# Altium schematic sheet Power_Delivery.SchDoc(Power_Delivery)
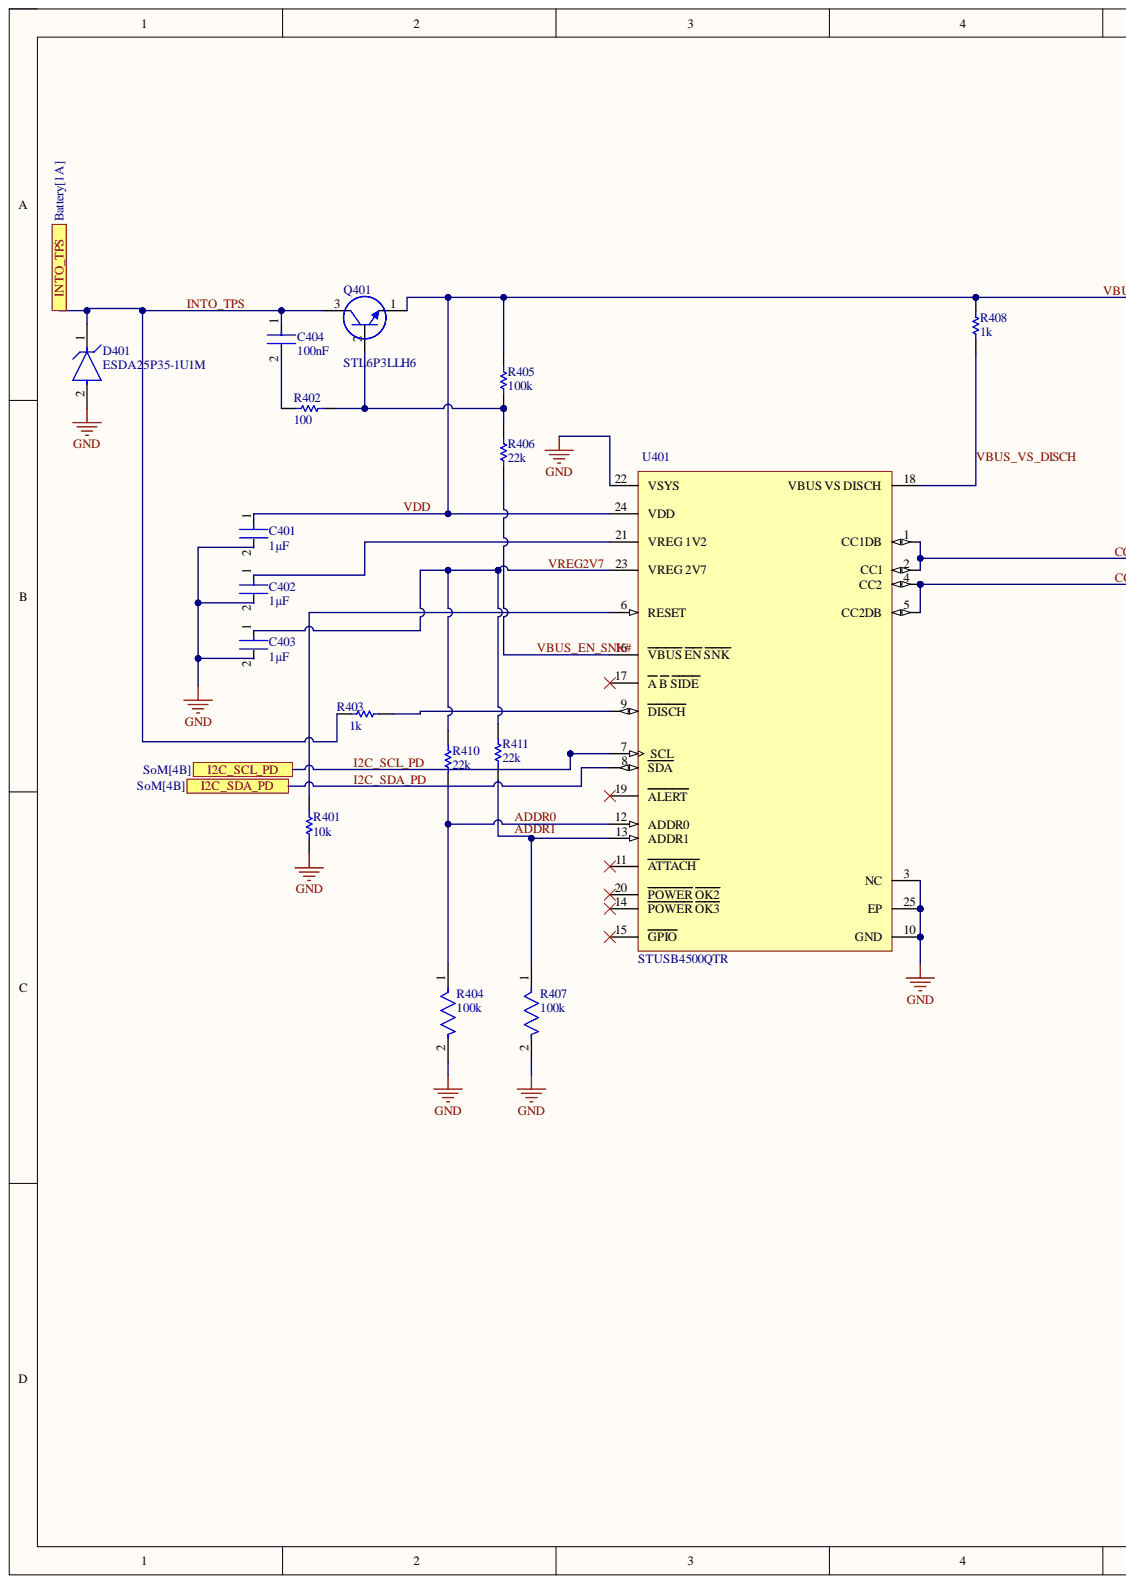
[diagram p0: Power_Delivery.SchDoc, left]
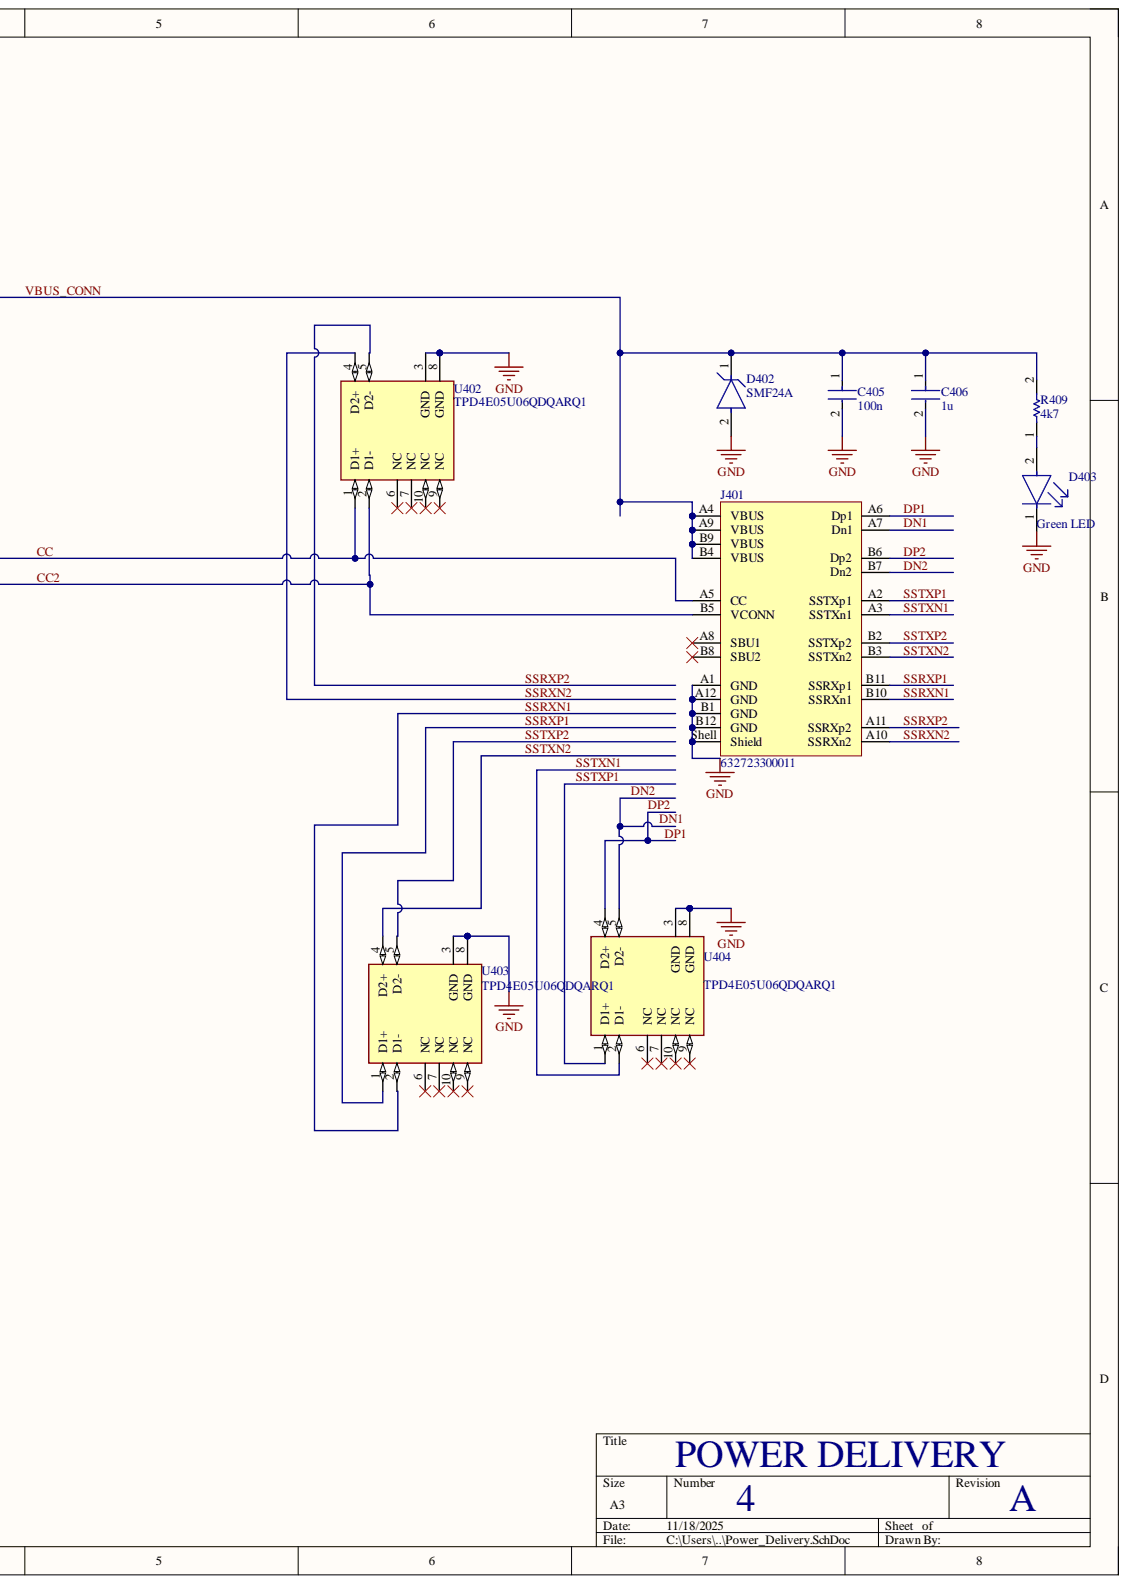
[diagram p1: Power_Delivery.SchDoc, right]

## Parts
C401  1µF  [FP-GRM15-0_1-MFG]
C402  1µF  [FP-GRM15-0_1-MFG]
C403  1µF  [FP-GRM15-0_1-MFG]
C404  100nF  [FP-GRM155-0_05-IPC_B]
C405  100n  [FP-GRM155-0_05-IPC_B]
C406  1u  [FP-GRM155-0_1-IPC_C]
D401  ESDA25P35-1U1M  [FP-QFN_1610-MFG]
D402  SMF24A  [FP-SMF24A-MFG]
D403  Green LED  Color: Green  [FP-LTST-C190GKT-MFG]
J401  632723300011  [632723300011]
Q401  STL6P3LLH6  [TRANS_STL6P3LLH6]
R401  10k  [RESC1005X40X25LL05T10]
R402  100  [RESC1005X40X25ML05T10]
R403  1k  [RESC3216X60X45ML15T20]
R404  100k  [FP-RC0402-0_4-IPC_A]
R405  100k  [RESC1005X40X25NL05T10]
R406  22k  [RESC1005X40X25NL05T05]
R407  100k  [FP-RC0402-0_4-IPC_A]
R408  1k  [RESC3216X60X45ML15T20]
R409  4k7  [RESC1005X40X25NL10T10]
R410  22k  [RESC1005X40X25NL05T10]
R411  22k  [RESC1005X40X25NL05T10]
U401  STUSB4500QTR  [FP-QFN-24-EP_4x4-MFG]
U402  TPD4E05U06QDQARQ1  [FP-DQA0010A-MFG]
U403  TPD4E05U06QDQARQ1  [FP-DQA0010A-MFG]
U404  TPD4E05U06QDQARQ1  [FP-DQA0010A-MFG]

## Ports
I2C_SCL_PD, I2C_SDA_PD, INTO_TPS

## Nets
GND: C401.2 C402.2 C403.2 C405.2 C406.2 D401.2 D402.2 D403.1 J401.A1(GND) J401.A12(GND) J401.B1(GND) J401.B12(GND) J401.Shell(Shield) R401.1 R404.2 R407.2 U401.3(NC) U401.10(GND) U401.22(VSYS) U401.25(EP) U402.3(GND) U402.8(GND) U403.3(GND) U403.8(GND) U404.3(GND) U404.8(GND)
ADDR0: R404.1 R410.1 U401.12(ADDR0)
ADDR1: R407.1 R411.1 U401.13(ADDR1)
CC: J401.A5(CC) U401.1(CC1DB) U401.2(CC1) U402.1(D1+)
CC2: J401.B5(VCONN) U401.4(CC2) U401.5(CC2DB) U402.2(D1-)
DN1: J401.A7(Dn1) J401.B7(Dn2) U404.5(D2-)
DP1: J401.A6(Dp1) J401.B6(Dp2) U404.4(D2+)
I2C_SCL_PD: U401.7(SCL) ; port I2C_SCL_PD
I2C_SDA_PD: U401.8(SDA) ; port I2C_SDA_PD
INTO_TPS: C404.1 D401.1 Q401.3 R403.2 ; port INTO_TPS
SSRXN1: J401.B10(SSRXn1) U403.2(D1-)
SSRXN2: J401.A10(SSRXn2) U402.4(D2+)
SSRXP1: J401.B11(SSRXp1) U403.1(D1+)
SSRXP2: J401.A11(SSRXp2) U402.5(D2-)
SSTXN1: J401.A3(SSTXn1) U404.2(D1-)
SSTXN2: J401.B3(SSTXn2) U403.4(D2+)
SSTXP1: J401.A2(SSTXp1) U404.1(D1+)
SSTXP2: J401.B2(SSTXp2) U403.5(D2-)
VBUS_CONN: C401.1 C405.1 C406.1 D402.1 J401.A4(VBUS) J401.A9(VBUS) J401.B4(VBUS) J401.B9(VBUS) Q401.1 R405.2 R408.2 R409.2 U401.24(VDD)
VBUS_EN_SNK#: R406.1 U401.16(VBUS_EN_SNK)
VBUS_VS_DISCH: R408.1 U401.18(VBUS_VS_DISCH)
VREG2V7: C403.1 R410.2 R411.2 U401.23(VREG_2V7)
NetC402_1: C402.1 U401.21(VREG_1V2)
NetC404_2: C404.2 R402.1
NetD403_2: D403.2 R409.1
NetQ401_2: Q401.2 R402.2 R405.1 R406.2
NetR401_2: R401.2 U401.6(RESET)
NetR403_1: R403.1 U401.9(DISCH)

Not connected: J401 A8(SBU1) B8(SBU2); U401 11(ATTACH) 14(POWER_OK3) 15(GPIO) 17(A_B_SIDE) 19(ALERT) 20(POWER_OK2); U402 6(NC) 7(NC) 9(NC) 10(NC); U403 6(NC) 7(NC) 9(NC) 10(NC); U404 6(NC) 7(NC) 9(NC) 10(NC)

## Library data of the parts
C401-C403 = CMP-2008-04556-2: CAP CER 1UF 10V X5R 0402; Manufacturer: Murata; Manufacturer Part Number: GRM155R61A105KE15D; Material: Ceramic; Temperature Coefficient: 15%; Tolerance: 10%; Automotive: No; Capacitance: 1uF; Category: Cap; Component Kind: Standard; ComponentLink2Description: Datasheet; ComponentLink2URL: https://search.murata.co.jp/Ceramy/image/img/A01X/G101/ENG/GRM155R61A105KE15-01A.pdf; Device Class L1: Passive Components; Device Class L2: Capacitors; Device Class L3: Ceramic Capacitors; Digikey Description: CAP CER 1UF 10V X5R 0402; Height: 0.55mm; Ipc Land Pattern Name: CAPC10050X50; Lead Free: Yes; Library Name: Altium Content Vault; Mouser Description: 0402 1 uF 10 V ±10% Tolerance X5R Surface Mount Multilayer Ceramic Capacitor; Octopart: https://octopart.com/grm155r61a105ke15d-murata-197442; Package: GRM15-0.1; RoHS: TRUE; Temperature: -55°C to +85°C; Temperature Characteristic: X5R; Temperature Range High: +85°C; Temperature Range Low: -55°C; Value: 1uF; Voltage Rating: 10V
C404 = CMP-2008-04639-2: Chip Multilayer Ceramic Capacitors for General Purpose, 0402, 0.10uF, X7R, 15%, 10%, 50V; Dissipation Factor: 0.025%; Capacitance: 100nF; Case Code (Imperial): 0402; Case Code (Metric): 1005; Case/Package: 0402; Component Kind: Standard; Depth: 0.5mm; Dielectric: X7R; Dielectric Material: Ceramic; Height: 0.5mm; Lead Free: Lead Free; Length: 1mm; Library Name: Altium Content Vault; Material: Ceramic; Max Operating Temperature: 125°C; Min Operating Temperature: -55°C; Mount: Surface Mount; Package Quantity: 10000; Packaging: Tape and Reel; REACH SVHC: No SVHC; Resistor Type: Ceramic; Termination: SMD/SMT; Thickness: 0.022inch; Tolerance: 10%; Voltage: 50V; Voltage Rating: 50V; Voltage Rating (DC): 50V; Weight: 0.000212oz; Width: 0.5mm
C405 = CMP-06035-003982-1: Chip Multilayer Ceramic Capacitors for General Purpose, 0402, 0.10uF, X5R, 15%, 10%, 50V; Capacitance: 100nF; Dissipation Factor: 0.025%; Case Code (Imperial): 0402; Case Code (Metric): 1005; Case/Package: 0402; Component Kind: Standard; Depth: 0.5mm; Dielectric: X5R; Dielectric Material: Ceramic; Height: 0.5mm; Lead Free: Lead Free; Length: 1mm; Library Name: Altium Content Vault; Max Operating Temperature: 85°C; Min Operating Temperature: -55°C; Mount: Surface Mount; Package Quantity: 10000; Packaging: Tape and Reel; REACH SVHC: No SVHC; Resistor Type: Ceramic; Termination: SMD/SMT; Thickness: 0.022inch; Tolerance: 10%; Voltage: 50V; Voltage Rating: 50V; Voltage Rating (DC): 50V; Weight: 0.000212oz; Width: 0.02inch
C406 = CMP-06035-026280-1: Chip Multilayer Ceramic Capacitors for General Purpose, 0402, 1.0uF, X5R, 15%, 10%, 25V; Capacitance: 1uF; Component Kind: Standard; Dielectric: X5R; Length: 1mm; Library Name: Altium Content Vault; Thickness: 500um; Tolerance: 10%; Voltage Rating (DC): 25V; Width: 500um
D401 = CMP-12225-000030-1: TVS DIODE 22V 41V 1610; Manufacturer: STMicroelectronics; Breakdown Voltage: 23.3V; Clamping Voltage: 39V; Direction: Unidirectional; Max Junction Temp: +150°C; Number Of Channels: 1; Peak Pulse Current: 35A; Peak Pulse Power: 1400W; Standoff Height: 0.02mm; Automotive: No; Category: Diode; Component Kind: Standard; ComponentLink2Description: Datasheet; ComponentLink2URL: https://www.st.com/resource/en/datasheet/esda25p35-1u1m.pdf; Device Class L1: Circuit Protection; Device Class L2: TVS Diodes; Device Class L3: unset; Digikey Description: TVS DIODE 22V 41V 1610; Height: 0.6mm; Lead Free: Yes; Library Name: Altium Content Vault; Manufacturer Part Number: ESDA25P35-1U1M; Mouser Description: ESDA Series 1400 W 25 V Uni-Directional Surface Mount TVS Diode - QFN-1610; Octopart: https://octopart.com/esda25p35-1u1m-stmicroelectronics-75302699; Package: QFN_1610; Reverse Standoff Voltage: 22V; RoHS: TRUE; Temperature: -55°C to +150°C; Temperature Range High: +150°C; Temperature Range Low: -55°C
D402 = CMP-08607-000108-1: TVS DIODE 24V 38.9V SOD123F; Breakdown Voltage: 29.5V; Leakage Current: 1uA; Max Surge Current: 5.1A; Min Breakdown Voltage: 26.7V; Number of Channels: 1; Peak Pulse Power: 200W; Polarity: Unidirectional; Power Line Protection: No; Test Current: 1mA; Clamping Voltage: 38.9V; Component Kind: Standard; Composition: Zener; Lead Free: Lead Free; Library Name: Altium Content Vault; Max Breakdown Voltage: 29.5V; Max Operating Temperature: 150°C; Min Operating Temperature: -55°C; Mount: Surface Mount; Number of Elements: 1; Number of Pins: 2; Number of Unidirectional Channels: 1; Packaging: Tape and Reel; Peak Pulse Current: 5.1A; Reverse Breakdown Voltage: 26.7V; Reverse Standoff Voltage: 24V; Termination: SMD/SMT
D403 = CMP-22017-000005-2: LED GREEN CLEAR CHIP SMD; Dominant Wavelength: 569nm; Forward Current: 30mA; Forward Voltage: 2.1V; Lens Color: Colorless; Luminous Intensity: 6mcd; Number of Elements: 1; Power Dissipation: 100mW; Reverse Voltage: 5V; Test Current: 10mA; Viewing Angle: 130°; Voltage: 2V; Wavelength: 565nm; Case Code (Imperial): 0603; Case Code (Metric): 1608; Case/Package: 0603; Component Kind: Standard; Depth: 0.8mm; Height: 0.8mm; Illumination Color: Green; Lead Free: Lead Free; Length: 1.6mm; Lens Style: Clear; Lens Transparency: Clear; Library Name: Altium Content Vault; Max Operating Temperature: 85°C; Min Operating Temperature: -55°C; Mount: Surface Mount; Number of LEDs: 1; Number of Pins: 2; Package Quantity: 1; Packaging: Tape and Reel; Power Rating: 100mW; Radiation Hardening: No; Width: 0.8mm
J401 = CMP-002-00072-1: Conn USB 3.1 Type C RCP 24 POS 0.5mm/0.4mm Solder RA SMD/Thru-Hole 24 Terminal 1 Port T/R; Gender: Receptacle; Voltage Rating: 20V; Component Kind: Standard; Connector Type: USB; Max Operating Temperature: 105°C; Min Operating Temperature: -40°C; Mounting Technology: Surface Mount, Through Hole; Pins: 24; Library Name: Evan
Q401 = CMP-013-00034-1: P-channel 30 V, 0.024 Ohm typ., 6 A STripFET H6 Power MOSFET in a PowerFLAT 3.3 x 3.3 package; Component Kind: Standard; Max Operating Temperature: 150°C; Mounting Technology: Surface Mount; Pins: 8; Library Name: Evan
R401 = CMP-2002-07353-1: Manufacturer: Yageo / Phycomp; Part Number: RC0402FR-0710KL; Component Kind: Standard; Library Name: Altium Content Vault
R402 = CMP-2002-07344-1: Manufacturer: Yageo / Phycomp; Part Number: RC0402FR-07100RL; Component Kind: Standard; Library Name: Altium Content Vault
R403 R408 = CMP-2100-03616-1: Chip Resistor, 1 KOhm, +/- 1%, 0.25 W, -55 to 155 degC, 1206 (3216 Metric), RoHS, Tape and Reel; Manufacturer: Vishay Semiconductor; Max Power Dissipation: 250mW; Resistance: 1KOhm; Temperature Coefficient: 100ppm/degreesC; Voltage Rating: 200V; Case Code (Imperial): 1206; Case Code (Metric): 3216; Case/Package: 1206; Component Kind: Standard; Composition: ThickFilm; Contact Plating: Tin; Datasheet URL: http://www.farnell.com/datasheets/1720486.pdf; Datasheet Version: 41061.0; Depth: 1.6mm; Height: 0.55mm; Lead Free: LeadFree; Length: 3.2mm; Library Name: Altium Content Vault; Manufacturer URL: http://www.vishay.com/; Max Operating Temperature: 155degC; Military Standard: Not; Min Operating Temperature: -55degC; Mount: SurfaceMount; Number of Pins: 2; Number of Terminations: 2; Package Description: 2-Pin Surface Mount Device, Body 3.15 x 1.6 mm; Package Quantity: 10; Package Reference: 1206.0; Packaging: TapeandReel; Power Rating: 250mW; Radiation Hardening: No; REACH SVHC: Unknown; RoHSCompliant: true; Termination: SMD/SMT; Tolerance: 1%; Voltage Rating (DC): 200V; Weight: 0.000353oz; Width: 0.063inch
R404 R407 = CMP-2002-07913-2: RES 100K OHM 5% 1/16W 0402; Max Power Dissipation: 63mW; Resistance: 100kR; Temperature Coefficient: 100ppm/°C; Voltage Rating: 50V; Case Code (Imperial): 0402; Case Code (Metric): 1005; Case/Package: 0402; Component Kind: Standard; Construction: Rectangular; Depth: 0.5mm; Features: Moisture Resistant; Height: 0.016inch; Lead Free: Lead Free; Length: 1mm; Library Name: Altium Content Vault; Max Operating Temperature: 155°C; Military Standard: Not; Min Operating Temperature: -55°C; Number of Terminations: 2; Package Quantity: 1; Packaging: Tape and Reel; Power Rating: 63mW; Resistance Tolerance: 5%; Resistor Type: Thick Film; RoHS Compliant: Yes; Series: RC; Size Code: 0402; Termination: SMD/SMT; Tolerance: 5%; Width: 0.02inch
R405 = CMP-2002-07343-1: Manufacturer: Yageo / Phycomp; Part Number: RC0402FR-07100KL; Component Kind: Standard; Library Name: Altium Content Vault
R406 = CMP-1011-00659-2: Manufacturer: Vishay Dale; Part Number: CRCW040222K0FKED; Component Kind: Standard; Library Name: Altium Content Vault
R409 = CMP-2002-05217-1: Manufacturer: Bourns; Part Number: CR0402-FX-4701GLF; Component Kind: Standard; Library Name: Altium Content Vault
R410 R411 = CMP-2002-07517-1: Manufacturer: Yageo / Phycomp; Part Number: RC0402FR-0722KL; Component Kind: Standard; Library Name: Altium Content Vault
U401 = CMP-12113-000003-3: 4.1V~22V USB 2.0 I2C Controllers Interface ICs STUSB45 Controller 24-VFQFN Exposed Pad; Interface: I2C, USB; Max Junction Temperature (Tj): 145°C; Max Supply Voltage: 22V; Min Supply Voltage: 3V; Number of Ports: 1; USB Standard: USB 2.0; Ambient Temperature Range High: 105°C; Component Kind: Standard; Height: 1mm; Library Name: Altium Content Vault; Lifecycle Status: Production; Max Operating Temperature: 105°C; Min Operating Temperature: -40°C; Schedule B: 8542390000, 8542390000
U402-U404 = CMP-04985-000004-1: TVS DIODE 5.5V 14V 10USON; Manufacturer: Texas Instruments; Automotive Grade: Grade 1; Breakdown Voltage: 6.4V; Capacitance: 0.5pF; Direction: Unidirectional; Interface: USB,Ethernet,LVDS,Other; Number Of Channels: 4; Peak Pulse Current: 2.5A; Peak Pulse Power: 2.5W; Standoff Height: 0mm; Value: 0.5pF; Ambient Temperature Range High: +125°C; Ambient Temperature Range Low: -40°C; Automotive: Yes; Category: IC; Clamping Voltage: 14V; Component Kind: Standard; ComponentLink2Description: Datasheet; ComponentLink2URL: http://www.ti.com/lit/ds/symlink/tpd4e05u06-q1.pdf; Device Class L1: Circuit Protection; Device Class L2: ESD and Circuit Protection ICs; Device Class L3: unset; Digikey Description: TVS DIODE 5.5V 14V 10USON; Height: 0.55mm; Lead Free: Yes; Library Name: Altium Content Vault; Manufacturer Part Number: TPD4E05U06QDQARQ1; Mouser Description: Automotive 4-Channel ESD Protection Solution for SuperSpeed (up to 5 Gbps) Interface 10-USON -40 to 125; Octopart: https://octopart.com/tpd4e05u06qdqarq1-texas+instruments-48523459; Operating Supply Voltage: 5.5V; Package: DQA0010A; Reverse Standoff Voltage: 5.5V; RoHS: TRUE; Temperature: -40°C to +125°C; Temperature Range High: +125°C; Temperature Range Low: -40°C
